# Altium schematic sheet K66_Sheet1.SchDoc
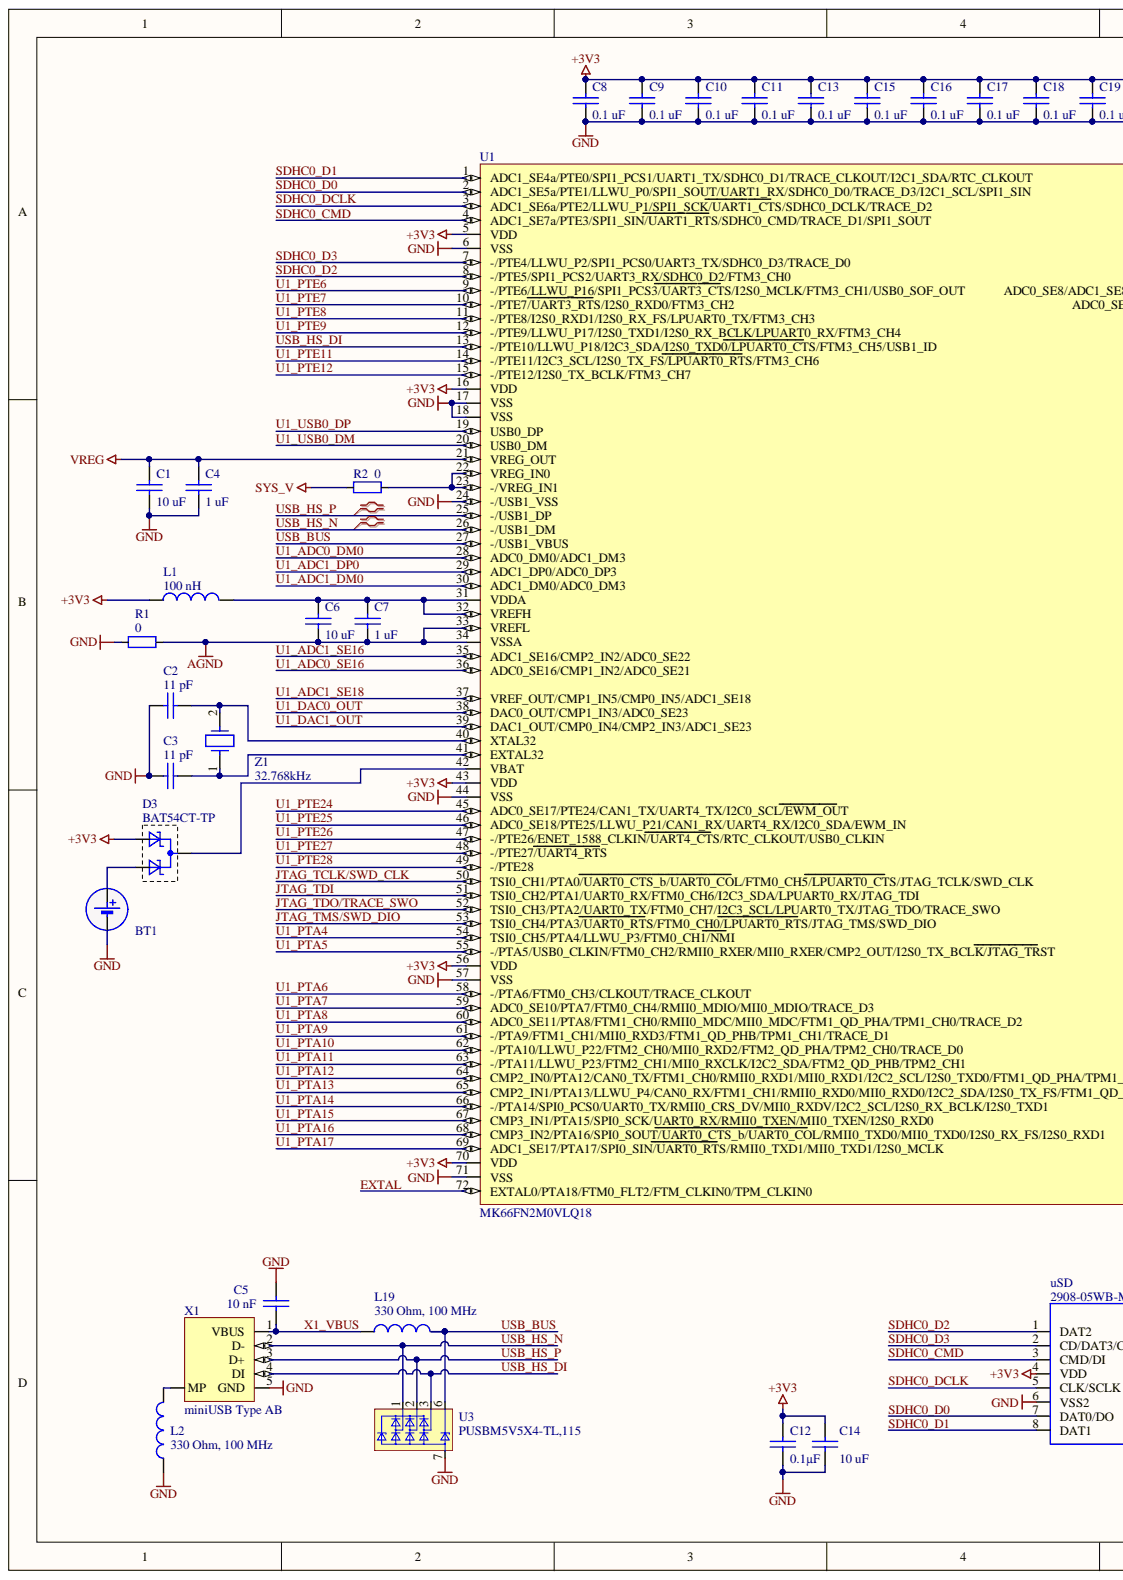
[diagram p0: K66_Sheet1.SchDoc, left]
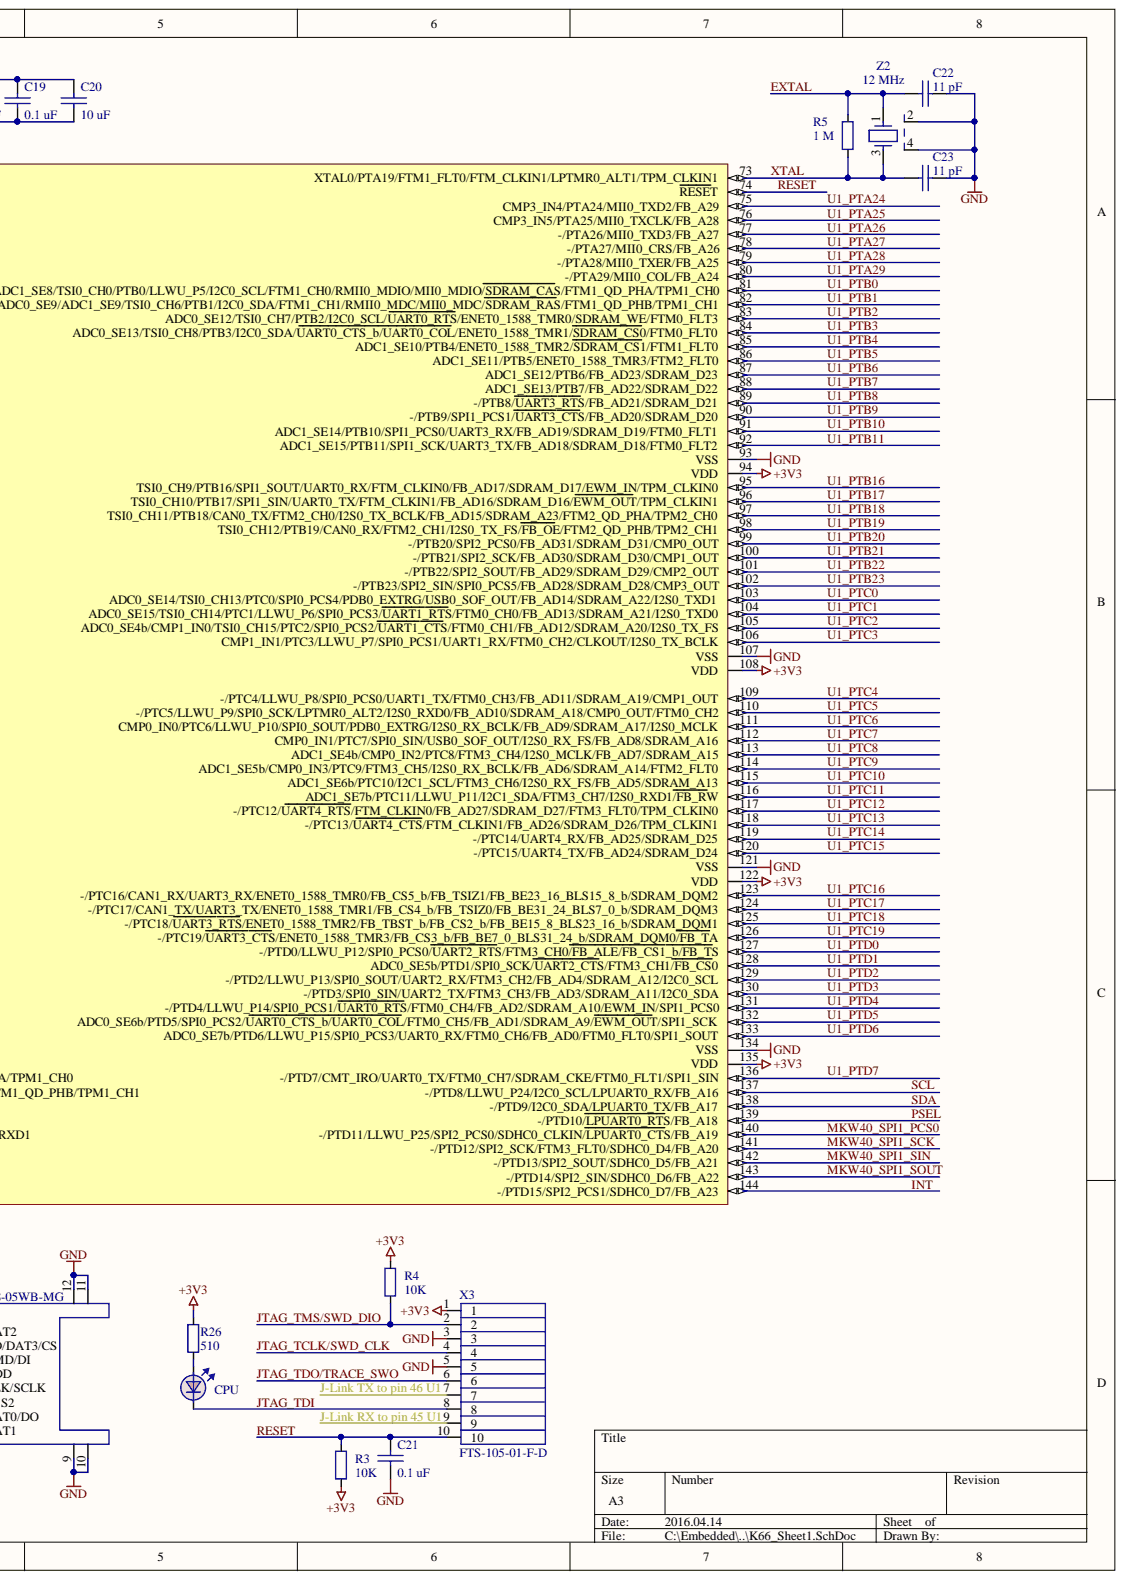
[diagram p1: K66_Sheet1.SchDoc, right]

## Parts
BT1  3000TR  [BATH_KEYSTONE_3000]  (value not drawn)
C1  value 10 uF  [1608[0603]]  (hidden comment: EMK107BBJ106MA-T)
C2  value 11 pF  [1005[0402]]  (hidden comment: 04023U110FAT2A)
C3  value 11 pF  [1005[0402]]  (hidden comment: 04023U110FAT2A)
C4  value 1 uF  [1608[0603]]  (hidden comment: CL10A105KO8NNNC)
C5  value 10 nF  [1608[0603]]  (hidden comment: CL10B103KC8NNNC)
C6  value 10 uF  [1608[0603]]  (hidden comment: EMK107BBJ106MA-T)
C7  value 1 uF  [1608[0603]]  (hidden comment: CL10A105KO8NNNC)
C8  value 0.1 uF  [1005[0402]]  (hidden comment: C0402C104K8PACTU)
C9  value 0.1 uF  [1005[0402]]  (hidden comment: C0402C104K8PACTU)
C10  value 0.1 uF  [1005[0402]]  (hidden comment: C0402C104K8PACTU)
C11  value 0.1 uF  [1005[0402]]  (hidden comment: C0402C104K8PACTU)
C12  value 0.1µF  [1608[0603]]  (hidden comment: CL10B104KA8NNND)
C13  value 0.1 uF  [1005[0402]]  (hidden comment: C0402C104K8PACTU)
C14  value 10 uF  [2012[0805]]  (hidden comment: 08053D106KAT2A)
C15  value 0.1 uF  [1005[0402]]  (hidden comment: C0402C104K8PACTU)
C16  value 0.1 uF  [1005[0402]]  (hidden comment: C0402C104K8PACTU)
C17  value 0.1 uF  [1005[0402]]  (hidden comment: C0402C104K8PACTU)
C18  value 0.1 uF  [1005[0402]]  (hidden comment: C0402C104K8PACTU)
C19  value 0.1 uF  [1005[0402]]  (hidden comment: C0402C104K8PACTU)
C20  value 10 uF  [1608[0603]]  (hidden comment: EMK107BBJ106MA-T)
C21  value 0.1 uF  [1005[0402]]  (hidden comment: C0402C104K8PACTU)
C22  value 11 pF  [1005[0402]]  (hidden comment: 04023U110FAT2A)
C23  value 11 pF  [1005[0402]]  (hidden comment: 04023U110FAT2A)
CPU  SML-211UTT86  [0805 LED]  (value not drawn)
D3  BAT54CT-TP  [SOT-523F]
L1  value 100 nH  [INDC1005-0402]  (hidden comment: MLG1005SR10JT000)
L2  value 330 Ohm, 100 MHz  [0603]  (hidden comment: BLM18SG331TN1D)
L19  value 330 Ohm, 100 MHz  [0603]  (hidden comment: BLM18SG331TN1D)
R1  value 0  [0402]  (hidden comment: MCR01MZPJ000)
R2  value 0  [0603]  (hidden comment: RL0603E000)
R3  value 10K  [0402]  (hidden comment: MCR01MZPJ103)
R4  value 10K  [0402]  (hidden comment: MCR01MZPJ103)
R5  value 1 M  [0603]  (hidden comment: RC0603FR-071ML)
R26  value 510  [0603]  (hidden comment: RL0603E510-1)
U1  MK66FN2M0VLQ18  [LQFP144]
U3  PUSBM5V5X4-TL,115  [DFN1616-6]
uSD  2908-05WB-MG  [2908-05WB-MG]
X1  miniUSB Type AB  [USB AB 56579-0576 Molex]
X3  FTS-105-01-F-D  [FTS-105-01-F-D]
Z1  value 32.768kHz  [FC-135 Epson]  (hidden comment: FC-135 32.7680KA-A3)
Z2  value 12 MHz  [SMD 3.2mm x 2.5mm]  (hidden comment: 7M-12.000MEEQ-T)

## Nets
+3V3: C8.1 C9.1 C10.1 C11.1 C12.1 C13.1 C14.1 C15.1 C16.1 C17.1 C18.1 C19.1 C20.1 D3.1 L1.1 R3.1 R4.2 R26.2 U1.5(VDD) U1.16(VDD) U1.43(VDD) U1.56(VDD) U1.70(VDD) U1.94(VDD) U1.108(VDD) U1.122(VDD) U1.135(VDD) uSD.4(VDD) X3.1
AGND: C6.2 C7.2 R1.2 U1.33(VREFL) U1.34(VSSA)
GND: BT1.2 C1.2 C2.1 C3.1 C4.2 C5.2 C8.2 C9.2 C10.2 C11.2 C12.2 C13.2 C14.2 C15.2 C16.2 C17.2 C18.2 C19.2 C20.2 C21.2 C22.2 C23.2 L2.1 R1.1 U1.6(VSS) U1.17(VSS) U1.18(VSS) U1.24(-/USB1_VSS) U1.44(VSS) U1.57(VSS) U1.71(VSS) U1.93(VSS) U1.107(VSS) U1.121(VSS) U1.134(VSS) U3.7 uSD.6(VSS2) uSD.9 uSD.10 uSD.11 uSD.12 X1.5(GND) X3.3 X3.5 Z2.2 Z2.4
SYS_V: R2.1
VREG: C1.1 C4.1 U1.21(VREG_OUT)
EXTAL: C22.1 R5.2 U1.72(EXTAL0/PTA18/FTM0_FLT2/FTM_CLKIN0/TPM_CLKIN0) Z2.1
INT: U1.144(-/PTD15/SPI2_PCS1/SDHC0_D7/FB_A23)
JTAG_TCLK/SWD_CLK: U1.50(TSI0_CH1/PTA0/UART0_CTS_b/UART0_COL/FTM0_CH5/LPUART0_CTS/JTAG_TCLK/SWD_CLK) X3.4
JTAG_TDI: CPU.2 U1.51(TSI0_CH2/PTA1/UART0_RX/FTM0_CH6/I2C3_SDA/LPUART0_RX/JTAG_TDI) X3.8
JTAG_TDO/TRACE_SWO: U1.52(TSI0_CH3/PTA2/UART0_TX/FTM0_CH7/I2C3_SCL/LPUART0_TX/JTAG_TDO/TRACE_SWO) X3.6
JTAG_TMS/SWD_DIO: R4.1 U1.53(TSI0_CH4/PTA3/UART0_RTS/FTM0_CH0/LPUART0_RTS/JTAG_TMS/SWD_DIO) X3.2
MKW40_SPI1_PCS0: U1.140(-/PTD11/LLWU_P25/SPI2_PCS0/SDHC0_CLKIN/LPUART0_CTS/FB_A19)
MKW40_SPI1_SCK: U1.141(-/PTD12/SPI2_SCK/FTM3_FLT0/SDHC0_D4/FB_A20)
MKW40_SPI1_SIN: U1.142(-/PTD13/SPI2_SOUT/SDHC0_D5/FB_A21)
MKW40_SPI1_SOUT: U1.143(-/PTD14/SPI2_SIN/SDHC0_D6/FB_A22)
PSEL: U1.139(-/PTD10/LPUART0_RTS/FB_A18)
RESET: C21.1 R3.2 U1.74(RESET) X3.10
SCL: U1.137(-/PTD8/LLWU_P24/I2C0_SCL/LPUART0_RX/FB_A16)
SDA: U1.138(-/PTD9/I2C0_SDA/LPUART0_TX/FB_A17)
SDHC0_CMD: U1.4(ADC1_SE7a/PTE3/SPI1_SIN/UART1_RTS/SDHC0_CMD/TRACE_D1/SPI1_SOUT) uSD.3(CMD/DI)
SDHC0_D0: U1.2(ADC1_SE5a/PTE1/LLWU_P0/SPI1_SOUT/UART1_RX/SDHC0_D0/TRACE_D3/I2C1_SCL/SPI1_SIN) uSD.7(DAT0/DO)
SDHC0_D1: U1.1(ADC1_SE4a/PTE0/SPI1_PCS1/UART1_TX/SDHC0_D1/TRACE_CLKOUT/I2C1_SDA/RTC_CLKOUT) uSD.8(DAT1)
SDHC0_D2: U1.8(-/PTE5/SPI1_PCS2/UART3_RX/SDHC0_D2/FTM3_CH0) uSD.1(DAT2)
SDHC0_D3: U1.7(-/PTE4/LLWU_P2/SPI1_PCS0/UART3_TX/SDHC0_D3/TRACE_D0) uSD.2(CD/DAT3/CS)
SDHC0_DCLK: U1.3(ADC1_SE6a/PTE2/LLWU_P1/SPI1_SCK/UART1_CTS/SDHC0_DCLK/TRACE_D2) uSD.5(CLK/SCLK)
U1_ADC0_DM0: U1.28(ADC0_DM0/ADC1_DM3)
U1_ADC0_SE16: U1.36(ADC0_SE16/CMP1_IN2/ADC0_SE21)
U1_ADC1_DM0: U1.30(ADC1_DM0/ADC0_DM3)
U1_ADC1_DP0: U1.29(ADC1_DP0/ADC0_DP3)
U1_ADC1_SE16: U1.35(ADC1_SE16/CMP2_IN2/ADC0_SE22)
U1_ADC1_SE18: U1.37(VREF_OUT/CMP1_IN5/CMP0_IN5/ADC1_SE18)
U1_DAC0_OUT: U1.38(DAC0_OUT/CMP1_IN3/ADC0_SE23)
U1_DAC1_OUT: U1.39(DAC1_OUT/CMP0_IN4/CMP2_IN3/ADC1_SE23)
U1_PTA4: U1.54(TSI0_CH5/PTA4/LLWU_P3/FTM0_CH1/NMI)
U1_PTA5: U1.55(-/PTA5/USB0_CLKIN/FTM0_CH2/RMII0_RXER/MII0_RXER/CMP2_OUT/I2S0_TX_BCLK/JTAG_TRST)
U1_PTA6: U1.58(-/PTA6/FTM0_CH3/CLKOUT/TRACE_CLKOUT)
U1_PTA7: U1.59(ADC0_SE10/PTA7/FTM0_CH4/RMII0_MDIO/MII0_MDIO/TRACE_D3)
U1_PTA8: U1.60(ADC0_SE11/PTA8/FTM1_CH0/RMII0_MDC/MII0_MDC/FTM1_QD_PHA/TPM1_CH0/TRACE_D2)
U1_PTA9: U1.61(-/PTA9/FTM1_CH1/MII0_RXD3/FTM1_QD_PHB/TPM1_CH1/TRACE_D1)
U1_PTA10: U1.62(-/PTA10/LLWU_P22/FTM2_CH0/MII0_RXD2/FTM2_QD_PHA/TPM2_CH0/TRACE_D0)
U1_PTA11: U1.63(-/PTA11/LLWU_P23/FTM2_CH1/MII0_RXCLK/I2C2_SDA/FTM2_QD_PHB/TPM2_CH1)
U1_PTA12: U1.64(CMP2_IN0/PTA12/CAN0_TX/FTM1_CH0/RMII0_RXD1/MII0_RXD1/I2C2_SCL/I2S0_TXD0/FTM1_QD_PHA/TPM1_CH0)
U1_PTA13: U1.65(CMP2_IN1/PTA13/LLWU_P4/CAN0_RX/FTM1_CH1/RMII0_RXD0/MII0_RXD0/I2C2_SDA/I2S0_TX_FS/FTM1_QD_PHB/TPM1_CH1)
U1_PTA14: U1.66(-/PTA14/SPI0_PCS0/UART0_TX/RMII0_CRS_DV/MII0_RXDV/I2C2_SCL/I2S0_RX_BCLK/I2S0_TXD1)
U1_PTA15: U1.67(CMP3_IN1/PTA15/SPI0_SCK/UART0_RX/RMII0_TXEN/MII0_TXEN/I2S0_RXD0)
U1_PTA16: U1.68(CMP3_IN2/PTA16/SPI0_SOUT/UART0_CTS_b/UART0_COL/RMII0_TXD0/MII0_TXD0/I2S0_RX_FS/I2S0_RXD1)
U1_PTA17: U1.69(ADC1_SE17/PTA17/SPI0_SIN/UART0_RTS/RMII0_TXD1/MII0_TXD1/I2S0_MCLK)
U1_PTA24: U1.75(CMP3_IN4/PTA24/MII0_TXD2/FB_A29)
U1_PTA25: U1.76(CMP3_IN5/PTA25/MII0_TXCLK/FB_A28)
U1_PTA26: U1.77(-/PTA26/MII0_TXD3/FB_A27)
U1_PTA27: U1.78(-/PTA27/MII0_CRS/FB_A26)
U1_PTA28: U1.79(-/PTA28/MII0_TXER/FB_A25)
U1_PTA29: U1.80(-/PTA29/MII0_COL/FB_A24)
U1_PTB0: U1.81(ADC0_SE8/ADC1_SE8/TSI0_CH0/PTB0/LLWU_P5/I2C0_SCL/FTM1_CH0/RMII0_MDIO/MII0_MDIO/SDRAM_CAS/FTM1_QD_PHA/TPM1_CH0)
U1_PTB1: U1.82(ADC0_SE9/ADC1_SE9/TSI0_CH6/PTB1/I2C0_SDA/FTM1_CH1/RMII0_MDC/MII0_MDC/SDRAM_RAS/FTM1_QD_PHB/TPM1_CH1)
U1_PTB2: U1.83(ADC0_SE12/TSI0_CH7/PTB2/I2C0_SCL/UART0_RTS/ENET0_1588_TMR0/SDRAM_WE/FTM0_FLT3)
U1_PTB3: U1.84(ADC0_SE13/TSI0_CH8/PTB3/I2C0_SDA/UART0_CTS_b/UART0_COL/ENET0_1588_TMR1/SDRAM_CS0/FTM0_FLT0)
U1_PTB4: U1.85(ADC1_SE10/PTB4/ENET0_1588_TMR2/SDRAM_CS1/FTM1_FLT0)
U1_PTB5: U1.86(ADC1_SE11/PTB5/ENET0_1588_TMR3/FTM2_FLT0)
U1_PTB6: U1.87(ADC1_SE12/PTB6/FB_AD23/SDRAM_D23)
U1_PTB7: U1.88(ADC1_SE13/PTB7/FB_AD22/SDRAM_D22)
U1_PTB8: U1.89(-/PTB8/UART3_RTS/FB_AD21/SDRAM_D21)
U1_PTB9: U1.90(-/PTB9/SPI1_PCS1/UART3_CTS/FB_AD20/SDRAM_D20)
U1_PTB10: U1.91(ADC1_SE14/PTB10/SPI1_PCS0/UART3_RX/FB_AD19/SDRAM_D19/FTM0_FLT1)
U1_PTB11: U1.92(ADC1_SE15/PTB11/SPI1_SCK/UART3_TX/FB_AD18/SDRAM_D18/FTM0_FLT2)
U1_PTB16: U1.95(TSI0_CH9/PTB16/SPI1_SOUT/UART0_RX/FTM_CLKIN0/FB_AD17/SDRAM_D17/EWM_IN/TPM_CLKIN0)
U1_PTB17: U1.96(TSI0_CH10/PTB17/SPI1_SIN/UART0_TX/FTM_CLKIN1/FB_AD16/SDRAM_D16/EWM_OUT/TPM_CLKIN1)
U1_PTB18: U1.97(TSI0_CH11/PTB18/CAN0_TX/FTM2_CH0/I2S0_TX_BCLK/FB_AD15/SDRAM_A23/FTM2_QD_PHA/TPM2_CH0)
U1_PTB19: U1.98(TSI0_CH12/PTB19/CAN0_RX/FTM2_CH1/I2S0_TX_FS/FB_OE/FTM2_QD_PHB/TPM2_CH1)
U1_PTB20: U1.99(-/PTB20/SPI2_PCS0/FB_AD31/SDRAM_D31/CMP0_OUT)
U1_PTB21: U1.100(-/PTB21/SPI2_SCK/FB_AD30/SDRAM_D30/CMP1_OUT)
U1_PTB22: U1.101(-/PTB22/SPI2_SOUT/FB_AD29/SDRAM_D29/CMP2_OUT)
U1_PTB23: U1.102(-/PTB23/SPI2_SIN/SPI0_PCS5/FB_AD28/SDRAM_D28/CMP3_OUT)
U1_PTC0: U1.103(ADC0_SE14/TSI0_CH13/PTC0/SPI0_PCS4/PDB0_EXTRG/USB0_SOF_OUT/FB_AD14/SDRAM_A22/I2S0_TXD1)
U1_PTC1: U1.104(ADC0_SE15/TSI0_CH14/PTC1/LLWU_P6/SPI0_PCS3/UART1_RTS/FTM0_CH0/FB_AD13/SDRAM_A21/I2S0_TXD0)
U1_PTC2: U1.105(ADC0_SE4b/CMP1_IN0/TSI0_CH15/PTC2/SPI0_PCS2/UART1_CTS/FTM0_CH1/FB_AD12/SDRAM_A20/I2S0_TX_FS)
U1_PTC3: U1.106(CMP1_IN1/PTC3/LLWU_P7/SPI0_PCS1/UART1_RX/FTM0_CH2/CLKOUT/I2S0_TX_BCLK)
U1_PTC4: U1.109(-/PTC4/LLWU_P8/SPI0_PCS0/UART1_TX/FTM0_CH3/FB_AD11/SDRAM_A19/CMP1_OUT)
U1_PTC5: U1.110(-/PTC5/LLWU_P9/SPI0_SCK/LPTMR0_ALT2/I2S0_RXD0/FB_AD10/SDRAM_A18/CMP0_OUT/FTM0_CH2)
U1_PTC6: U1.111(CMP0_IN0/PTC6/LLWU_P10/SPI0_SOUT/PDB0_EXTRG/I2S0_RX_BCLK/FB_AD9/SDRAM_A17/I2S0_MCLK)
U1_PTC7: U1.112(CMP0_IN1/PTC7/SPI0_SIN/USB0_SOF_OUT/I2S0_RX_FS/FB_AD8/SDRAM_A16)
U1_PTC8: U1.113(ADC1_SE4b/CMP0_IN2/PTC8/FTM3_CH4/I2S0_MCLK/FB_AD7/SDRAM_A15)
U1_PTC9: U1.114(ADC1_SE5b/CMP0_IN3/PTC9/FTM3_CH5/I2S0_RX_BCLK/FB_AD6/SDRAM_A14/FTM2_FLT0)
U1_PTC10: U1.115(ADC1_SE6b/PTC10/I2C1_SCL/FTM3_CH6/I2S0_RX_FS/FB_AD5/SDRAM_A13)
U1_PTC11: U1.116(ADC1_SE7b/PTC11/LLWU_P11/I2C1_SDA/FTM3_CH7/I2S0_RXD1/FB_RW)
U1_PTC12: U1.117(-/PTC12/UART4_RTS/FTM_CLKIN0/FB_AD27/SDRAM_D27/FTM3_FLT0/TPM_CLKIN0)
U1_PTC13: U1.118(-/PTC13/UART4_CTS/FTM_CLKIN1/FB_AD26/SDRAM_D26/TPM_CLKIN1)
U1_PTC14: U1.119(-/PTC14/UART4_RX/FB_AD25/SDRAM_D25)
U1_PTC15: U1.120(-/PTC15/UART4_TX/FB_AD24/SDRAM_D24)
U1_PTC16: U1.123(-/PTC16/CAN1_RX/UART3_RX/ENET0_1588_TMR0/FB_CS5_b/FB_TSIZ1/FB_BE23_16_BLS15_8_b/SDRAM_DQM2)
U1_PTC17: U1.124(-/PTC17/CAN1_TX/UART3_TX/ENET0_1588_TMR1/FB_CS4_b/FB_TSIZ0/FB_BE31_24_BLS7_0_b/SDRAM_DQM3)
U1_PTC18: U1.125(-/PTC18/UART3_RTS/ENET0_1588_TMR2/FB_TBST_b/FB_CS2_b/FB_BE15_8_BLS23_16_b/SDRAM_DQM1)
U1_PTC19: U1.126(-/PTC19/UART3_CTS/ENET0_1588_TMR3/FB_CS3_b/FB_BE7_0_BLS31_24_b/SDRAM_DQM0/FB_TA)
U1_PTD0: U1.127(-/PTD0/LLWU_P12/SPI0_PCS0/UART2_RTS/FTM3_CH0/FB_ALE/FB_CS1_b/FB_TS)
U1_PTD1: U1.128(ADC0_SE5b/PTD1/SPI0_SCK/UART2_CTS/FTM3_CH1/FB_CS0)
U1_PTD2: U1.129(-/PTD2/LLWU_P13/SPI0_SOUT/UART2_RX/FTM3_CH2/FB_AD4/SDRAM_A12/I2C0_SCL)
U1_PTD3: U1.130(-/PTD3/SPI0_SIN/UART2_TX/FTM3_CH3/FB_AD3/SDRAM_A11/I2C0_SDA)
U1_PTD4: U1.131(-/PTD4/LLWU_P14/SPI0_PCS1/UART0_RTS/FTM0_CH4/FB_AD2/SDRAM_A10/EWM_IN/SPI1_PCS0)
U1_PTD5: U1.132(ADC0_SE6b/PTD5/SPI0_PCS2/UART0_CTS_b/UART0_COL/FTM0_CH5/FB_AD1/SDRAM_A9/EWM_OUT/SPI1_SCK)
U1_PTD6: U1.133(ADC0_SE7b/PTD6/LLWU_P15/SPI0_PCS3/UART0_RX/FTM0_CH6/FB_AD0/FTM0_FLT0/SPI1_SOUT)
U1_PTD7: U1.136(-/PTD7/CMT_IRO/UART0_TX/FTM0_CH7/SDRAM_CKE/FTM0_FLT1/SPI1_SIN)
U1_PTE6: U1.9(-/PTE6/LLWU_P16/SPI1_PCS3/UART3_CTS/I2S0_MCLK/FTM3_CH1/USB0_SOF_OUT)
U1_PTE7: U1.10(-/PTE7/UART3_RTS/I2S0_RXD0/FTM3_CH2)
U1_PTE8: U1.11(-/PTE8/I2S0_RXD1/I2S0_RX_FS/LPUART0_TX/FTM3_CH3)
U1_PTE9: U1.12(-/PTE9/LLWU_P17/I2S0_TXD1/I2S0_RX_BCLK/LPUART0_RX/FTM3_CH4)
U1_PTE11: U1.14(-/PTE11/I2C3_SCL/I2S0_TX_FS/LPUART0_RTS/FTM3_CH6)
U1_PTE12: U1.15(-/PTE12/I2S0_TX_BCLK/FTM3_CH7)
U1_PTE24: U1.45(ADC0_SE17/PTE24/CAN1_TX/UART4_TX/I2C0_SCL/EWM_OUT)
U1_PTE25: U1.46(ADC0_SE18/PTE25/LLWU_P21/CAN1_RX/UART4_RX/I2C0_SDA/EWM_IN)
U1_PTE26: U1.47(-/PTE26/ENET_1588_CLKIN/UART4_CTS/RTC_CLKOUT/USB0_CLKIN)
U1_PTE27: U1.48(-/PTE27/UART4_RTS)
U1_PTE28: U1.49(-/PTE28)
U1_USB0_DM: U1.20(USB0_DM)
U1_USB0_DP: U1.19(USB0_DP)
USB_BUS: L19.2 U1.27(-/USB1_VBUS) U3.6
USB_HS_DI: U1.13(-/PTE10/LLWU_P18/I2C3_SDA/I2S0_TXD0/LPUART0_CTS/FTM3_CH5/USB1_ID) U3.3 X1.4(DI)
USB_HS_N: U1.26(-/USB1_DM) U3.1 X1.2(D-)
USB_HS_P: U1.25(-/USB1_DP) U3.2 X1.3(D+)
X1_VBUS: C5.1 L19.1 X1.1(VBUS)
XTAL: C23.1 R5.1 U1.73(XTAL0/PTA19/FTM1_FLT0/FTM_CLKIN1/LPTMR0_ALT1/TPM_CLKIN1) Z2.3
NetBT1_1: BT1.1 D3.2
NetC2_2: C2.2 U1.40(XTAL32) Z1.2
NetC3_2: C3.2 U1.41(EXTAL32) Z1.1
NetC6_1: C6.1 C7.1 L1.2 U1.31(VDDA) U1.32(VREFH)
NetCPU_1: CPU.1 R26.1
NetD3_3: D3.3 U1.42(VBAT)
NetL2_2: L2.2 X1.MP
NetR2_2: R2.2 U1.22(VREG_IN0) U1.23(-/VREG_IN1)

Not connected: X3 7 9

## Library data of the parts
BT1 = 3000TR: HOLDER BATTERY COIN 12MM DIA SMD; Manufacturer: Keystone Electronics; PartNum: 36-3000CT-ND; Component Kind: Standard; Distributor: Digi-Key; PartName: 3000TR; Library Name: ea_Batt_Holders.SchLib
C1 C6 = EMK107BBJ106MA-T: 10 uF, 16V, X5R, 20%, 0603, -55°C ~ 85°C; Manufacturer: Taiyo Yuden; PartNum: 587-3238-1-ND; Value: 10 uF; Component Kind: Standard; Distributor: Digi-Key; PartName: EMK107BBJ106MA-T; Tolerance: 20%; Library Name: ea_Capacitors.SCHLIB
C2 C3 C22 C23 = 04023U110FAT2A: 11 pF, 25V, +-1%, NP0/COG, 0402, -55..+125; Manufacturer: AVX Corporation; PartNum: 04023U110FAT2A-ND; Component Kind: Standard; Distributor: Digi-Key; PartName: 04023U110FAT2A; Tolerance: +-1%; Library Name: ea_Capacitors.SCHLIB
C4 C7 = CL10A105KO8NNNC: 1.0 uF, 16V, 10%, X5R, 0603, -55..85 С; Manufacturer: Samsung; PartNum: 1276-1034-1-ND; Component Kind: Standard; Distributor: Digi-Key; PartName: CL10A105KO8NNNC; Tolerance: 10%; Library Name: ea_Capacitors.SCHLIB
C5 = CVNF010K0603: 10 nF, 100V, 10%, X7R, 0603 SMD MLC Capacitor; Manufacturer: SAMSUNG; Component Kind: Standard; Distributor: TME; PartName: CL10B103KC8NNNC; PartNum: CL10B103KC8NNNC; Price: 0.00467; Tolerance: ±10%; Voltage: 100V; Library Name: ea_Capacitors.SCHLIB
C8 = C0402C104K8PACTU: 0.1 uF, 10V, 10% , X5R,  0402, -55°C ~ 85°C; Manufacturer: Kemet; PartNum: 399-3027-1-ND; Tolerance: +-10%; Value: 0.1 uF; Component Kind: Standard; Distributor: DigiKey; PartName: C0402C104K8PACTU; Library Name: ea_Capacitors.SCHLIB
C9-C11 C13 C15-C19 C21 = C0402C104K8PACTU: 0.1 uF, 10V, 10% , X5R,  0402, -55°C ~ 85°C; Manufacturer: Kemet; PartNum: 399-3027-1-ND; Tolerance: +-10%; Component Kind: Standard; Distributor: DigiKey; PartName: C0402C104K8PACTU; Library Name: ea_Capacitors.SCHLIB
C12 = CVNF100K0603-25: 0.1 uF, 50V, 10% , X7R,  0603 SMD MLC Capacitor; Manufacturer: WALSIN; PartName: 0603B104K500CT; PartNum: 2496834; Component Kind: Standard; Distributor: Farnell; Price: 0.0026 Euro; Tolerance: ± 10%; Library Name: ea_Capacitors.SCHLIB
C14 = C2012X5R1C106K/0.85: 10 uF, 25V, X5R, ±10%, 0805; Manufacturer: AVX Corporation; Component Kind: Standard; Distributor: TME; PartName: 08053D106KAT2A; PartNum: 08053D106KAT2A; Price: 0.0593; Tolerance: ±10%; Voltage: 25V; Library Name: ea_Capacitors.SCHLIB
C20 = EMK107BBJ106MA-T: 10 uF, 16V, X5R, 20%, 0603, -55°C ~ 85°C; Manufacturer: Taiyo Yuden; PartNum: 587-3238-1-ND; Component Kind: Standard; Distributor: Digi-Key; PartName: EMK107BBJ106MA-T; Tolerance: 20%; Library Name: ea_Capacitors.SCHLIB
CPU = SML-211UTT86: 0805 red LED, hibright, 2 mA, 1.8V, 130°; Manufacturer: Rohm; PartNum: 511-1292-1-ND; Component Kind: Standard; Distributor: Digi-Key; PartName: SML-211UTT86; Price: 0.34$; Value: Red; Library Name: ea_Indicators.SCHLIB
D3 = BAT54CT-TP: Schottky Diode, 30 V, 200 mA, common cathode, SOT523; Manufacturer: Micro Commercial Co; PartNum: BAT54CT-TPCT-ND; Component Kind: Standard; Distributor: Digi-Key; PartName: BAT54CT-TP; Price: 0.52$; Library Name: ea_Diodes.SCHLIB
L1 = MLG1005SR10JT000: 100nH, ±5%, 200 мА, 2 Ohm, 0402, -55..+125 C; Manufacturer: TDK Corporation; PartNum: 445-3071-1-ND; Component Kind: Standard; Distributor: Digi-Key; PartName: MLG1005SR10JT000; Tolerance: ±5%; Library Name: ea_Inductors.SCHLIB
L2 L19 = BLM18SG331TN1D: Ferrite bead, 330 Ohm, 100 MHz,  1.5 A, 0.07 Ohm; Manufacturer: Murata Electronics North America; Tolerance: 25%; Component Kind: Standard; Distributor: Future; PartName: BLM18SG331TN1D; PartNum: BLM18SG331TN1D; Price: 0.03 Euro
  L2: Value: 330 Ohm, 100 MHz
R1 = MCR01MZPJ000: 0 Ohm, 0402, Thick Film, -55..+155; Manufacturer: Rohm Semiconductor; PartNum: RHM0.0JCT-ND; Component Kind: Standard; Distributor: Digi-Key; PartName: MCR01MZPJ000; Price: 0.1$; Library Name: ea_Resistors.SCHLIB
R2 = RL0603E000: 0 R, 1%, 0603, SMD Resistor, Taped; Manufacturer: Vishay; Voltage: 75 V; Component Kind: Standard; Distributor: Schukat; PartName: RL0603E000; PartNum: RL0603E000; Price: 0.002 Euro; Tolerance: 1%; Library Name: ea_Resistors.SCHLIB
R3 R4 = MCR01MZPJ103: 10 K, 0402, Thick Film, -55..+155; Manufacturer: Rohm Semiconductor; PartNum: RHM10KJTR-ND; Tolerance: 5%; Component Kind: Standard; Distributor: Digi-Key; PartName: MCR01MZPJ103; Price: 0.1$; Library Name: ea_Resistors.SCHLIB
R5 = RC0603FR-071ML: 1 M, 1%, 0603, SMD Resistor, Taped; Manufacturer: YAGEO AMERICA CORPORATION; Component Kind: Standard; Distributor: Future; PartName: RC0603FR-071ML; PartNum: RC0603FR-071ML; Price: 0.002 Euro; Tolerance: 1%; Library Name: ea_Resistors.SCHLIB
R26 = RL0603E510-1: 510 R, 1%, 0603, SMD Resistor, Taped; Manufacturer: Vishay; Component Kind: Standard; Distributor: Schukat; PartName: RL0603E510-1; PartNum: RL0603E510-1; Price: 0.002 Euro; Tolerance: 1%; Library Name: ea_Resistors.SCHLIB
U1 = MK66FN2M0VLQ18: IC MCU 32BIT 2MB FLASH, 256KB RAM, 144QFP; Manufacturer: Freescale; PartNum: MK66FN2M0VLQ18-ND; Component Kind: Standard; Distributor: Digi-Key; PartName: MK66FN2M0VLQ18; Price: 15.4$; Library Name: ea_IC_CPU.SCHLIB
U3 = PUSBM5V5X4-TL,115: TVS DIODE 5.5VWM 12VC 6HXSON; Manufacturer: NXP Semiconductors; PartNum: 568-10921-1-ND; Component Kind: Standard; Distributor: Digi-Key; PartName: PUSBM5V5X4-TL,115; Library Name: ea_TVS_protection.SchLib
uSD = 2908-05WB-MG: microSD cadr holder; Manufacturer: Amphenol Commercial Products; PartName: 101-00660-68-6; PartNum: 101-00660-68-6-1-ND; Component Kind: Standard; Distributor: Digi-Key; Price: 1.97$; Library Name: ea_CARD_holders.SCHLIB
X1 = USB AB 56579-0576: SMD USB - OTG, miniUSB Type AB; Manufacturer: Molex/Waldom Electronics Corp; PartName: 0565790576; PartNum: WM17122CT-ND; Component Kind: Standard; Distributor: Digi-Key; Price: 1.71$; Library Name: ea_Connectors.SCHLIB
X3 = FTS-105-01-F-D: Board-To-Board Connector, Vertical, FTS Series, Through Hole, Header, 10, 1.27 mm; Manufacturer: SAMTEC; PartNum: 1928242; Component Kind: Standard; Distributor: Farnell; PartName: FTS-105-01-F-D; Library Name: ea_JTAG_SWD.SCHLIB
Z1 = FC-135 32.7680KA-A3: 32.768kHz ±20ppm Crystal 12.5pF 70 kOhm -40°C ~ 85°C Surface Mount 2-SMD; Manufacturer: EPSON; PartNum: SE2413CT-ND; Component Kind: Standard; Distributor: Digi-Key; PartName: FC-135 32.7680KA-A3; Tolerance: ±20ppm; Library Name: ea_OSC_CLK_GEN.SCHLIB
Z2 = 7M-12.000MEEQ-T: 12 MHz, 10 pF, +-10 ppm, -40..+85 С; Manufacturer: TXC; PartNum: 2308719; Tolerance: 10 ppm; Component Kind: Standard; Distributor: Farnell; PartName: 7M-12.000MEEQ-T; Library Name: ea_OSC_CLK_GEN.SCHLIB
